# Altium schematic sheet CORE.SchDoc(CORE)
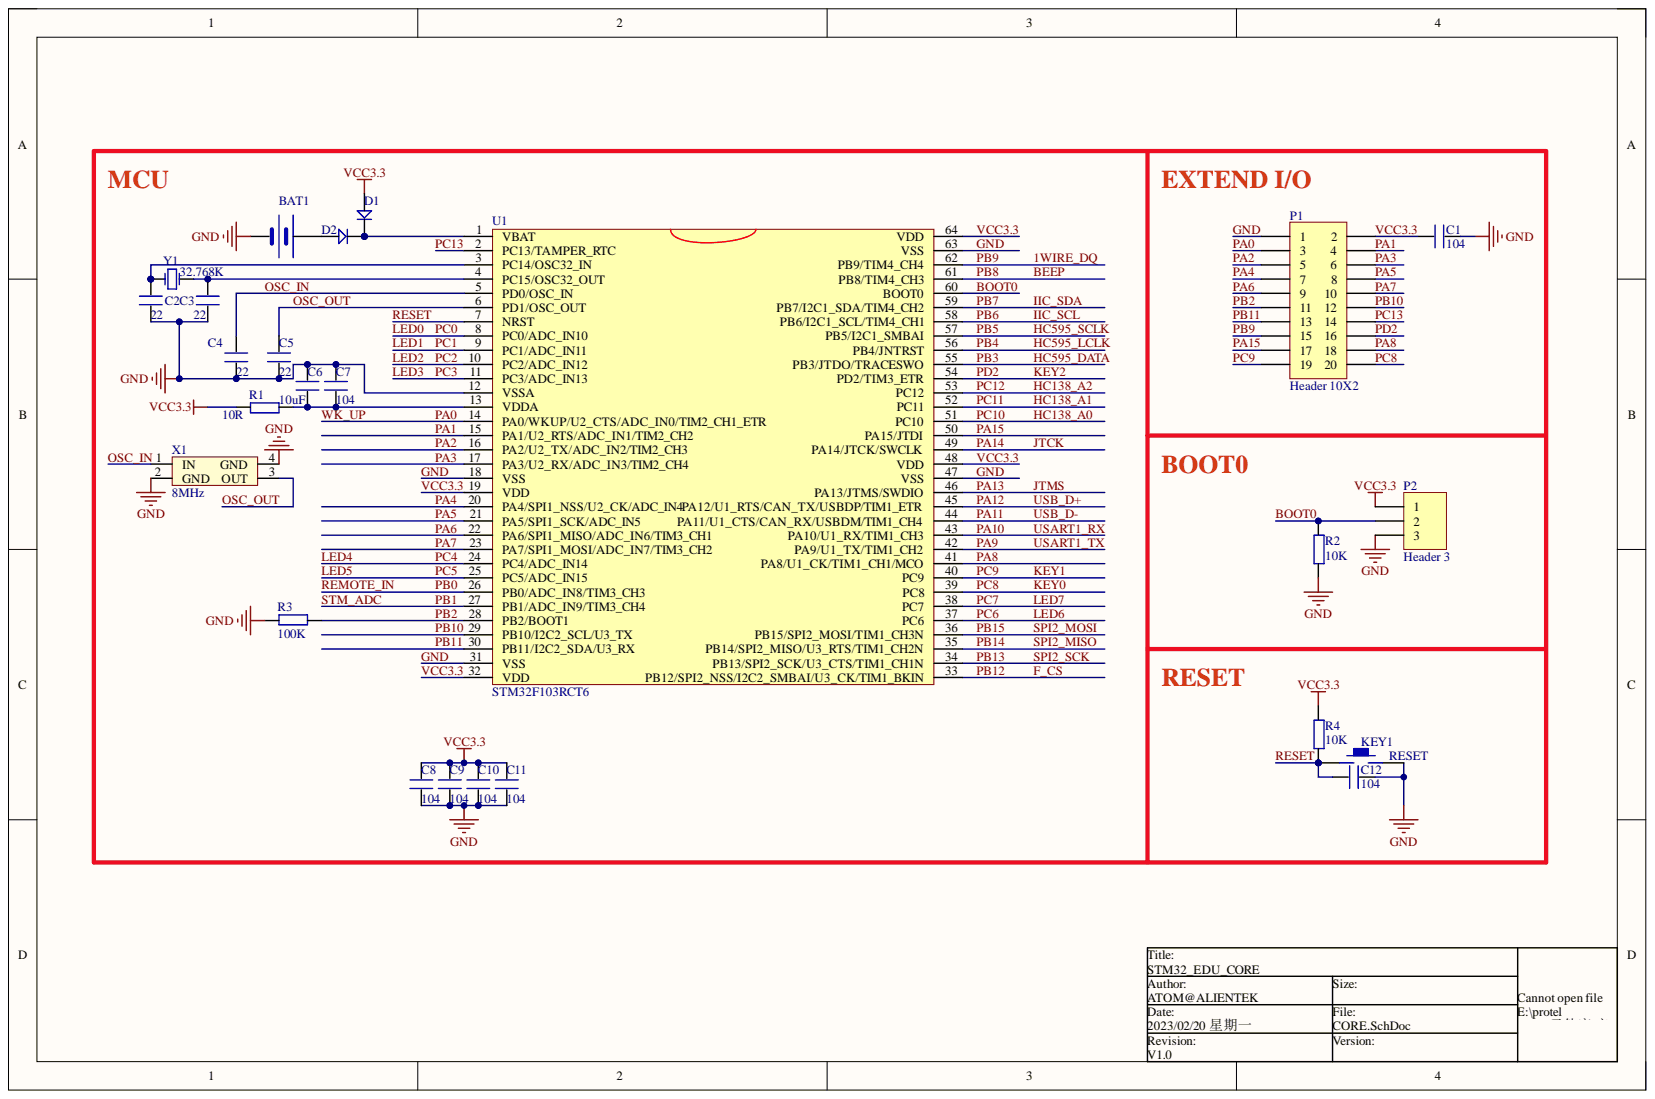
[diagram p0: CORE.SchDoc]

## Parts
BAT1  BATT  [BATM]  (value not drawn)
C1  104  [0603P]
C2  22  [0603P]
C3  22  [0603P]
C4  22  [0603P]
C5  22  [0603P]
C6  10uF  [0805]
C7  104  [0603P]
C8  104  [0603P]
C9  104  [0603P]
C10  104  [0603P]
C11  104  [0603P]
C12  104  [0603P]
D1  Diode  [DIODE_SOP]  (value not drawn)
D2  Diode  [DIODE_SOP]  (value not drawn)
KEY1  RESET  [KEY_SOP324225]
P1  Header 10X2  [HDR2X10]
P2  Header 3  [HDR1X3]
R1  10R  [0603P]
R2  10K  [0603P]
R3  100K  [0603P]
R4  10K  [0603P]
U1  STM32F103RCT6  [LQFP64]
X1  8MHz  [XTAL3225   无源]
Y1  32.768K  [XTAL3215]

## Nets
1WIRE_DQ: P1.15 U1.62(PB9/TIM4_CH4)
BEEP: U1.61(PB8/TIM4_CH3)
BOOT0: P2.2 R2.2 U1.60(BOOT0)
F_CS: U1.33(PB12/SPI2_NSS/I2C2_SMBAI/U3_CK/TIM1_BKIN)
GND: BAT1.2 C1.1 C2.1 C3.1 C4.1 C5.1 C6.2 C7.2 C8.1 C9.1 C10.1 C11.1 C12.1 KEY1.2 P1.1 P2.3 R2.1 R3.2 U1.12(VSSA) U1.18(VSS) U1.31(VSS) U1.47(VSS) U1.63(VSS) X1.2(GND) X1.4(GND)
HC138_A0: U1.51(PC10)
HC138_A1: U1.52(PC11)
HC138_A2: U1.53(PC12)
HC595_DATA: U1.55(PB3/JTDO/TRACESWO)
HC595_LCLK: U1.56(PB4/JNTRST)
HC595_SCLK: U1.57(PB5/I2C1_SMBAI)
IIC_SCL: U1.58(PB6/I2C1_SCL/TIM4_CH1)
IIC_SDA: U1.59(PB7/I2C1_SDA/TIM4_CH2)
JTCK: U1.49(PA14/JTCK/SWCLK)
JTMS: U1.46(PA13/JTMS/SWDIO)
KEY0: P1.20 U1.39(PC8)
KEY1: P1.19 U1.40(PC9)
KEY2: P1.16 U1.54(PD2/TIM3_ETR)
LED0: U1.8(PC0/ADC_IN10)
LED1: U1.9(PC1/ADC_IN11)
LED2: U1.10(PC2/ADC_IN12)
LED3: U1.11(PC3/ADC_IN13)
LED4: U1.24(PC4/ADC_IN14)
LED5: U1.25(PC5/ADC_IN15)
LED6: U1.37(PC6)
LED7: U1.38(PC7)
OSC_IN: C4.2 U1.5(PD0/OSC_IN) X1.1(IN)
OSC_OUT: C5.2 U1.6(PD1/OSC_OUT) X1.3(OUT)
PA0: P1.3 U1.14(PA0/WKUP/U2_CTS/ADC_IN0/TIM2_CH1_ETR)
PA1: P1.4 U1.15(PA1/U2_RTS/ADC_IN1/TIM2_CH2)
PA2: P1.5 U1.16(PA2/U2_TX/ADC_IN2/TIM2_CH3)
PA3: P1.6 U1.17(PA3/U2_RX/ADC_IN3/TIM2_CH4)
PA4: P1.7 U1.20(PA4/SPI1_NSS/U2_CK/ADC_IN4)
PA5: P1.8 U1.21(PA5/SPI1_SCK/ADC_IN5)
PA6: P1.9 U1.22(PA6/SPI1_MISO/ADC_IN6/TIM3_CH1)
PA7: P1.10 U1.23(PA7/SPI1_MOSI/ADC_IN7/TIM3_CH2)
PA8: P1.18 U1.41(PA8/U1_CK/TIM1_CH1/MCO)
PA9: U1.42(PA9/U1_TX/TIM1_CH2)
PA10: U1.43(PA10/U1_RX/TIM1_CH3)
PA11: U1.44(PA11/U1_CTS/CAN_RX/USBDM/TIM1_CH4)
PA12: U1.45(PA12/U1_RTS/CAN_TX/USBDP/TIM1_ETR)
PA15: P1.17 U1.50(PA15/JTDI)
PB0: U1.26(PB0/ADC_IN8/TIM3_CH3)
PB1: U1.27(PB1/ADC_IN9/TIM3_CH4)
PB2: P1.11 R3.1 U1.28(PB2/BOOT1)
PB10: P1.12 U1.29(PB10/I2C2_SCL/U3_TX)
PB11: P1.13 U1.30(PB11/I2C2_SDA/U3_RX)
PB13: U1.34(PB13/SPI2_SCK/U3_CTS/TIM1_CH1N)
PB14: U1.35(PB14/SPI2_MISO/U3_RTS/TIM1_CH2N)
PB15: U1.36(PB15/SPI2_MOSI/TIM1_CH3N)
PC13: P1.14 U1.2(PC13/TAMPER_RTC)
RESET: C12.2 KEY1.1 R4.1 U1.7(NRST)
VCC3.3: C1.2 C8.2 C9.2 C10.2 C11.2 D1.1 P1.2 P2.1 R1.1 R4.2 U1.19(VDD) U1.32(VDD) U1.48(VDD) U1.64(VDD)
NetBAT1_1: BAT1.1 D2.1
NetC2_2: C2.2 U1.3(PC14/OSC32_IN) Y1.2
NetC3_2: C3.2 U1.4(PC15/OSC32_OUT) Y1.1
NetC6_1: C6.1 C7.1 R1.2 U1.13(VDDA)
NetD1_2: D1.2 D2.2 U1.1(VBAT)

## Library data of the parts
BAT1 = BAT: Component Kind: Standard; Library Name: MYCOMLIB.IntLib
C1-C12 = C: Component Kind: Standard; Library Name: MYCOMLIB.IntLib
D1 D2 = D: Component Kind: Standard; Library Name: MYCOMLIB.IntLib
KEY1 = KEY_M: Component Kind: Standard; Library Name: ALIENTEK_COMPONENT.IntLib
P1 = Header 10X2: Header, 10-Pin, Dual row; Component Kind: Standard; LatestRevisionDate: 17-Jul-2002; LatestRevisionNote: Re-released for DXP Platform.; Library Name: Miscellaneous Connectors.IntLib; Signal Integrity: Connector; Publisher: Altium Limited
P2 = Header 3: Header, 3-Pin; Component Kind: Standard; LatestRevisionDate: 17-Jul-2002; LatestRevisionNote: Re-released for DXP Platform.; Library Name: Miscellaneous Connectors.IntLib; Signal Integrity: Connector; Publisher: Altium Limited
R1-R4 = R: Component Kind: Standard; Library Name: MYCOMLIB.IntLib
U1 = STM32F103RBT6: Component Kind: Standard; Library Name: ALIENTEK_COMPONENT.IntLib
X1 = XTAL-无源贴片: 无源贴片晶振; Component Kind: Standard; Library Name: MiniFly .IntLib
Y1 = XTAL: 普通晶振; Component Kind: Standard; LatestRevisionDate: 17-Jul-2002; LatestRevisionNote: Re-released for DXP Platform.; Library Name: ALIENTEK_COMPONENT.IntLib; Published: 8-Jun-2000; Publisher: Altium Limited
